# Altium schematic sheet MAIN.SchDoc(MAIN)
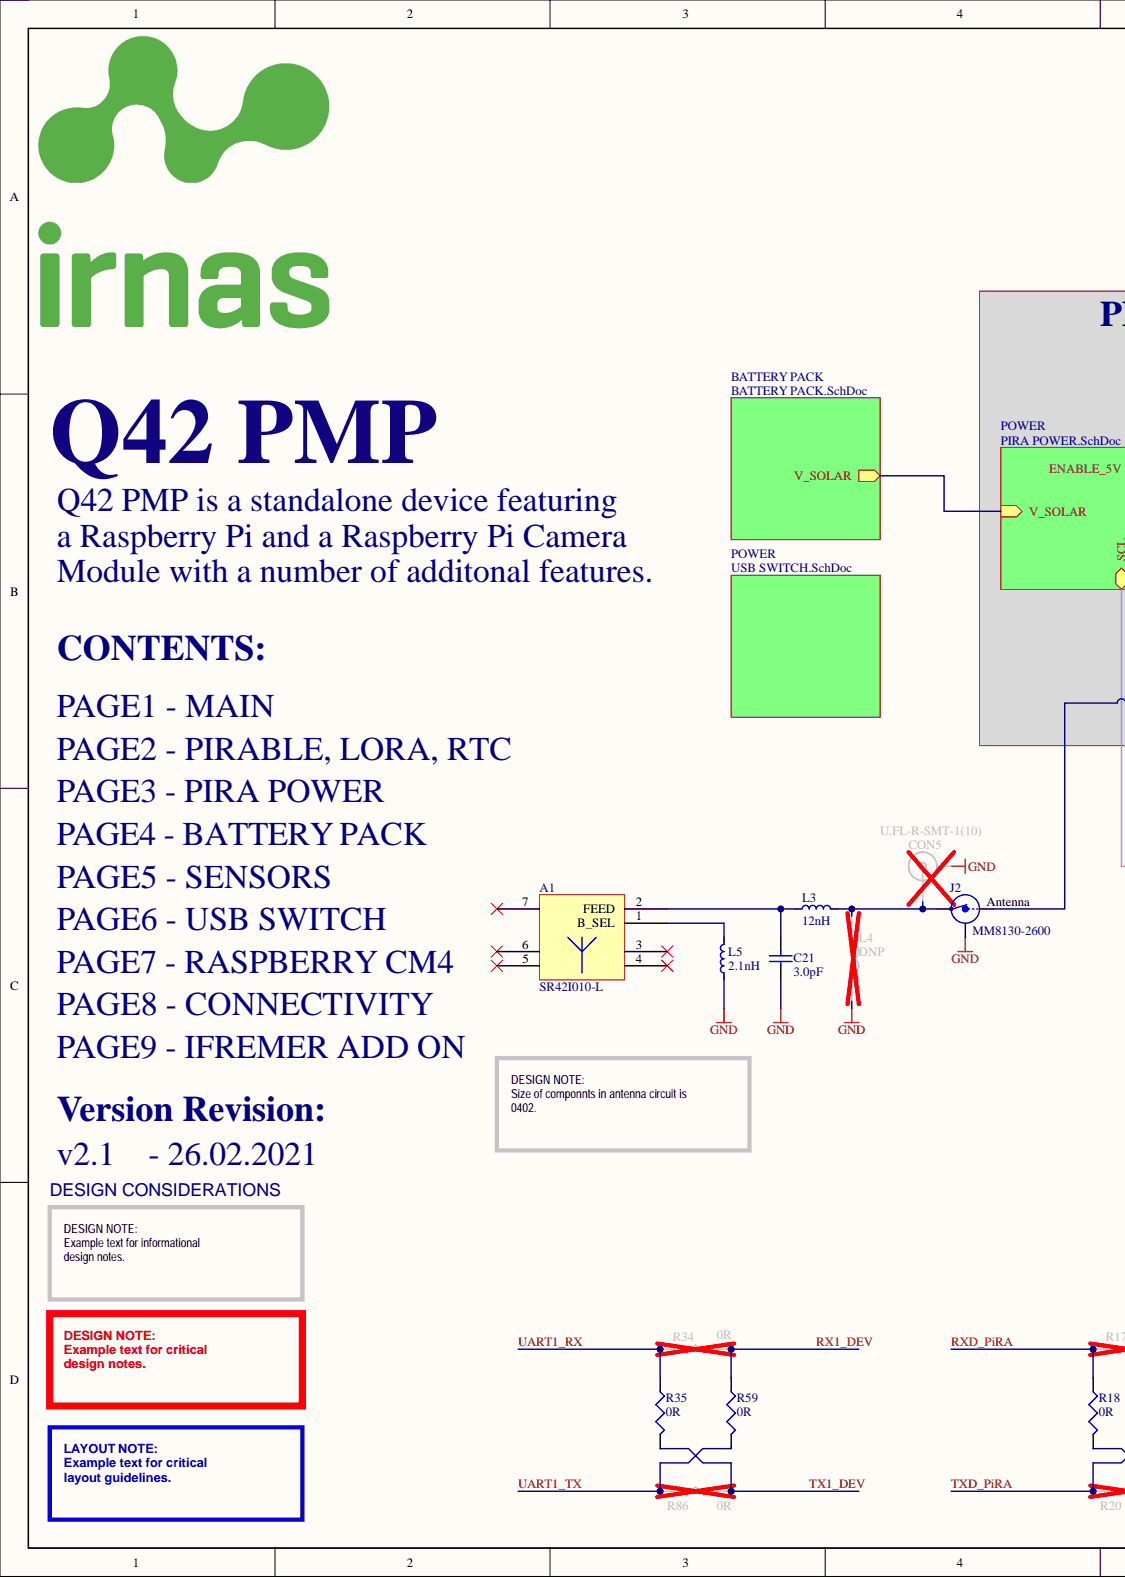
[diagram p0: MAIN.SchDoc, left]
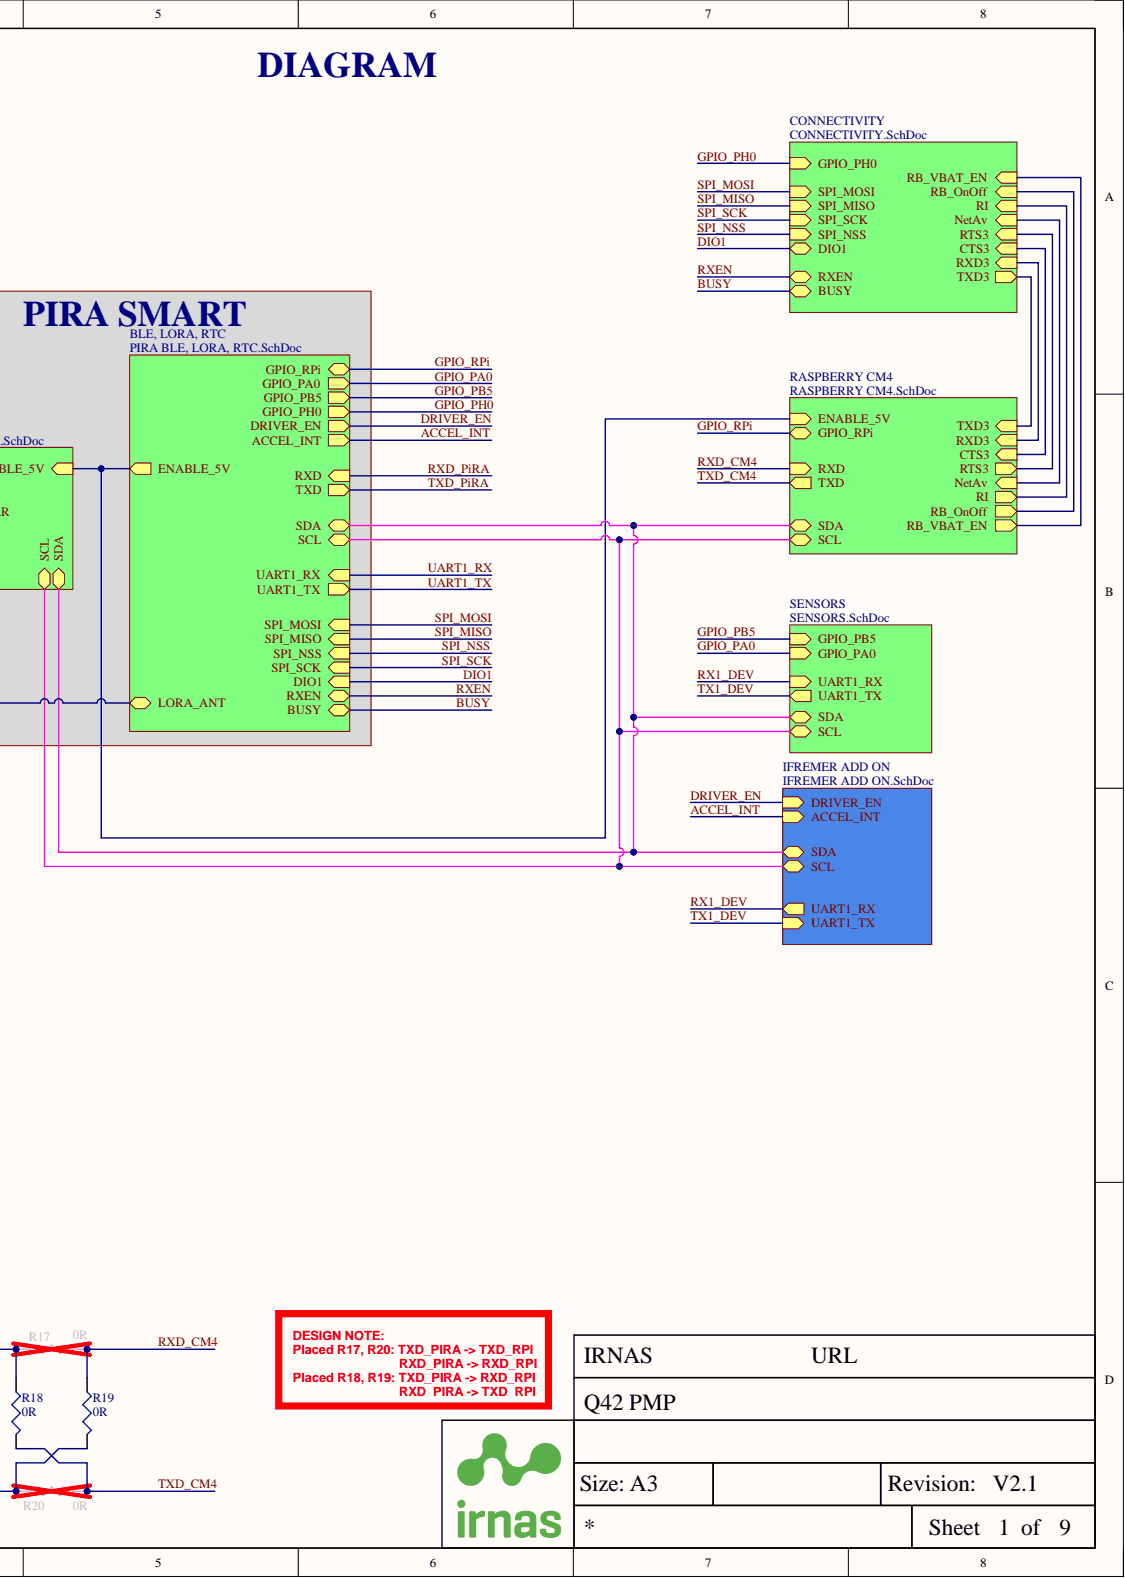
[diagram p1: MAIN.SchDoc, right]

## Parts
A1  SR42I010-L  [SR42I010-L]
C21  value 3.0pF  [CAP0402]  (hidden comment: 3.0pF/50V/0402)
CON5  U.FL-R-SMT-1(10)  [ufl connector smd]
J2  MM8130-2600  [COAXIAL-SWF]
L3  value 12nH  [IND0402]  (hidden comment: 10nH/0402)
L4  DNP  [IND0402]
L5  2.1nH  [IND0402]
R17  0R  [RES0603]
R18  0R  [RES0603]
R19  0R  [RES0603]
R20  0R  [RES0603]
R34  0R  [RES0603]
R35  0R  [RES0603]
R59  0R  [RES0603]
R86  0R  [RES0603]

## Nets
GND: C21.1 CON5.2 J2.2 L4.1 L5.1
RX1_DEV: R34.2 R59.1
RXD_CM4: R17.2 R19.1
RXD_PiRA: R17.1 R18.1
TX1_DEV: R35.2 R86.2
TXD_CM4: R18.2 R20.2
TXD_PiRA: R19.2 R20.1
UART1_RX: R34.1 R35.1
UART1_TX: R59.2 R86.1
NetA1_1: A1.1(B_SEL) L5.2
NetA1_2: A1.2(FEED) C21.2 L3.1
NetA1_3: A1.3
NetA1_4: A1.4
NetA1_5: A1.5
NetA1_6: A1.6
NetA1_7: A1.7
NetCON5_1: CON5.1 J2.3 L3.2 L4.2
NetJ2_1: J2.1
ACCEL_INT:
BUSY:
CTS3:
DIO1:
DRIVER_EN:
ENABLE_5V:
GPIO_PA0:
GPIO_PB5:
GPIO_PH0:
GPIO_RPi:
NetAv:
RB_OnOff:
RB_VBAT_EN:
RI:
RTS3:
RXD3:
RXEN:
SCL:
SDA:
SPI_MISO:
SPI_MOSI:
SPI_NSS:
SPI_SCK:
TXD3:
V_SOLAR:

## Library data of the parts
A1 = ANT - SR42I010-R_1: Antenna SMD -4dBi Gain 870MHz/928MHz Automotive 7-Pin SMD T/R; Manufacturer: Antenova; Component Kind: Standard; Library Name: Passive.SchLib; Manufacturer Part Number: SR42I010-R; Pricing 1: 1 = 2.36000, 10 = 1.90000, 50 = 1.90000, 100 = 1.52000, 1000 = 1.07000, 10000 = 0.95900 (USD); Stock 1: 3154; Supplier 1: Mouser; Supplier Part Number 1: 237-SR42I010-R
C21 = C - 3.0pF/50V/0402: CAP CER 3.0PF 50V C0G/NP0 0402; Component Kind: Standard; LatestRevisionDate: 05-oct-2007  -  Semtech; LatestRevisionNote: Re-released for DXP Platform.; Library Name: Passive.SchLib; Published: 8-Jun-2000; Supplier 1: Digi-Key; Supplier Part Number 1: 490-7756-1-ND; Publisher: Altium Limited
CON5 = U.FL-R-SMT-1(10): RF / Coaxial Connector, U.FL Coaxial, Straight Jack, Surface Mount Vertical, 50 ohm, Brass; Manufacturer: Hirose; Body Finish: Silver; Body Material: Phosphor Bronze; Center Contact Plating: Gold; Connector Style: U.FL, Ultra Miniature Coaxial; Connector Type: Receptacle, Male Pin; Contact Termination: Solder; Dielectric Material: Liquid Crystal Polymer (LCP); Featured Product: U.FL Series Connectors; Frequency - Max: 6GHz; Impedance: 50 Ohm; Online Catalog: UMCC (Ultra Miniature Coax Connectors), U.FL Series; Other Names: H11891TR  Q5400621  U.FL-R-SMT-1 10  U.FL-R-SMT-1(10)-ND  UFLRSMT110; Cable Group: -; Category: Connectors, Interconnects; Center Contact Material: Brass; Component Kind: Standard; ComponentLink1Description: http://www.farnell.com/datasheets/91524.pdf; ComponentLink1URL: http://www.farnell.com/datasheets/91524.pdf; ComponentLink2Description: http://uk.rs-online.com/webdocs/1363/0900766b81363563.pdf; ComponentLink2URL: http://uk.rs-online.com/webdocs/1363/0900766b81363563.pdf; ComponentLink3Description: http://www.farnell.com/datasheets/1947917.pdf; ComponentLink3URL: http://www.farnell.com/datasheets/1947917.pdf; Family: Coaxial Connectors (RF); Fastening Type: Snap-On; Features: -; Housing Color: Beige; Ingress Protection: -; Library Name: Connectors.SchLib; Manufacturer Part Number: U.FL-R-SMT-1(10); Mounting Type: Surface Mount; Operating Temperature: -40°C ~ 90°C; Packaging: Tape & Reel (TR); Series: U.FL; Shield Termination: Solder; Standard Package: 2,500; Stock 1: 0; Supplier 1: Farnell; Supplier Part Number 1: 1688077; Voltage Rating: -
J2 = Coaxial-Internal-SW: Coaxial Connector with Switch; 2nd source part number: 81-MM8130-2600RA2; Manufacturer: Murata; Artikkelnr Noca: 55029011; Artikkelnr Simpro: N.A.; 2nd source Supplier: Mouser; Component Kind: Standard; Datasheet: http://www.murata.com/products/catalog/pdf/o33e.pdf; Footprint Doc: COAXIAL-SWF; LatestRevisionDate: 2014-04-24; Library Name: Connectors.SchLib; Manufacturer Part Number: MM8130-2600; Part Number: MM8130-2600; Pin Count: 3; Published: 2014-04-24; Supplier: Digi-Key; Supplier part number: 490-4981-1-ND; Value: MM8130-2600; Publisher: Nordic Semiconductor
L3 = L - 12nH/500mA/0402: IND 12NH 500MA 280 MOHM; Code_IEC: 1005; Code_JEITA: 0402; Component Kind: Standard; LatestRevisionDate: 05-oct-2007  -  Semtech; LatestRevisionNote: Re-released for DXP Platform.; Library Name: Passive.SchLib; Published: 8-Jun-2000; Supplier 1: Digi-Key; Supplier Part Number 1: 490-2624-1-ND; Publisher: Altium Limited
L4 = L - 4.3nH/0402: Inductor RF Chip Multi-Layer 4.3nH 0.3nH 100MHz 8Q-Factor Air 300mA 210mOhm DCR 0402 Paper T/R; IRNAS Part No.: /; Manufacturer: Murata; Manufacturer Part Number: LQG15HN4N3S02D; DC Resistance (DCR): 210mR; Q Factor: 8; Self Resonant Frequency: 6GHz; Shielding: Unshielded; Case Code (Imperial): 0402; Case Code (Metric): 1005; Case/Package: 0402; Component Kind: Standard; ComponentLink1Description: http://uk.rs-online.com/webdocs/0f10/0900766b80f10808.pdf?src-supplier=RSComponents; ComponentLink1URL: http://uk.rs-online.com/webdocs/0f10/0900766b80f10808.pdf?src-supplier=RSComponents; ComponentLink2Description: http://search.murata.co.jp/Ceramy/image/img/PDF/ENG/L0075S0083LQG15HN.pdf?src-supplier=Avnet; ComponentLink2URL: http://search.murata.co.jp/Ceramy/image/img/PDF/ENG/L0075S0083LQG15HN.pdf?src-supplier=Avnet; ComponentLink3Description: http://www.farnell.com/datasheets/66026.pdf?src-supplier=Element14; ComponentLink3URL: http://www.farnell.com/datasheets/66026.pdf?src-supplier=Element14; ComponentLink4Description: https://www.verical.com/server-webapp/html/getDatasheet?partID=31252&src-supplier=Verical; ComponentLink4URL: https://www.verical.com/server-webapp/html/getDatasheet?partID=31252&src-supplier=Verical; ComponentLink5Description: http://search.murata.co.jp/Ceramy/image/img/PDF/ENG/L0075S0083LQG15HN.pdf?src-supplier=Avnet+Europe; ComponentLink5URL: http://search.murata.co.jp/Ceramy/image/img/PDF/ENG/L0075S0083LQG15HN.pdf?src-supplier=Avnet+Europe; ComponentLink6Description: https://search.murata.co.jp/Ceramy/image/img/P02/partnumberingAT_LQ3E.pdf?src-supplier=Digi-Key; ComponentLink6URL: https://search.murata.co.jp/Ceramy/image/img/P02/partnumberingAT_LQ3E.pdf?src-supplier=Digi-Key; Core Material: Air; Current: 300mA; Current Rating: 300mA; DC Current: 300mA; Depth: 0.55mm; Height: 0.5mm; Height - Seated (Max): 0.022inch; Inductance: 4.3nh; Lead Free: Lead Free; Length: 1.05mm; Library Name: Passive.SchLib; Max DC Current: 300mA; Max Operating Temperature: 125°C; Military Standard: Not; Min Operating Temperature: -55°C; Mount: Surface Mount; Package Quantity: 10000; Packaging: Tape and Reel; Pricing 1: 1 = 0.10000, 10 = 0.06100, 100 = 0.04040, 500 = 0.03366, 1000 = 0.02827, 2500 = 0.02423 (USD); Radiation Hardening: No; REACH SVHC: No SVHC; RoHS: Yes; Stock 1: 3502; Supplier 1: Farnell; Supplier Part Number 1: 1711735; Termination: SMD/SMT; Test Frequency: 100MHz; Width: 0.02inch
L5 = L - 2.1nH/0402: Multilayer Inductor, AEC-Q200, 2.1 nH, 0.06 ohm, 8 GHz, 1 A, 0402 [1005 Metric], LQG15WH_02 Series; Component Kind: Standard; Library Name: Passive.SchLib; Supplier 1: Farnell; Supplier Part Number 1: 3263552
R17-R20 R34 R35 R59 R86 = R - 0R: BOURNS - CR0603-J/-000ELF - RES, THICK FILM, 0R, 5%, 0.1W, 0603; IRNAS Part No.: I-100011; Power Rating: 100; Product Range: CR Series; Resistance: 0; Resistor Case Style: 0603 [1608 Metric]; Resistor Mounting: Surface Mount Device<!--FANDB--><div class="features-and-benefits-container"><div class="features-and-benefits-description"><span class="features-benefits-value">The Bourns CR series are standard thick film chip resistors. These resistors provide flexibility of performance, space saving and low cost. The Resistance range includes E96 and E24 decade values from 1ohm to 10Mohm.</span></div><div class="features-and-benefits-list"><ul class="features-and-benefits-list-list"><li class="features-and-benefits-list-item">0201, 0402, 0603, 0805, 1206, 1210, 2010, 2512 standard case sizes available</li><li class="features-and-benefits-list-item">±1% and ±5% tolerance</li><li class="features-and-benefits-list-item">±100ppm/°C, ±200ppm/°C and ±250ppm/°C TCR values available</li><li class="features-and-benefits-list-item">Power rating selectable for 50mW, 63mW, 0.1W, 0.125W, 0.25W, 0.5W and 1W based on ordering</li><li class="features-and-benefits-list-item">Jumpers are available for all size</li><li class="features-and-benefits-list-item">3 layer termination process with nickel barrier prevents leaching & provides excellent solderability</li><li class="features-and-benefits-list-item">Suitable for all type of soldering processes</li><li class="features-and-benefits-list-item">Tight dimensional tolerances</li><li class="features-and-benefits-list-item">Maximum working voltage depends on case size</li><li class="features-and-benefits-list-item">Operating temperature of -55°C to +125°C(CR0201) and -55°C to +155; SVHC: No SVHC (17-Dec-2015); Voltage Rating: 50; Automotive Qualification Standard: -; Component Kind: Standard; ComponentLink1Description: http://www.bourns.com/engineering/CHPREZTR/cr0603.stp; ComponentLink1URL: http://www.bourns.com/engineering/CHPREZTR/cr0603.stp; ComponentLink2Description: http://www.farnell.com/datasheets/2178762.pdf; ComponentLink2URL: http://www.farnell.com/datasheets/2178762.pdf; Library Name: Passive.SchLib; Manufacturer: BOURNS; Manufacturer Part Number: CR0603-J/-000ELF; MSL: MSL 1 - Unlimited; Packaging: Cut Tape; Pricing 1: 10=0.0146, 250=0.0097, 1000=0.0073, 5000=0.0049, 12500=0.0042 (GBP); Resistance Tolerance: ± 5%; Resistor Element Material: Thick Film; RoHS: YES; Stock 1: 58200; Supplier 1: Farnell; Supplier Part Number 1: 2008343; Temperature Coefficient: -
